# Altium schematic sheet mcu.SchDoc(mcu)
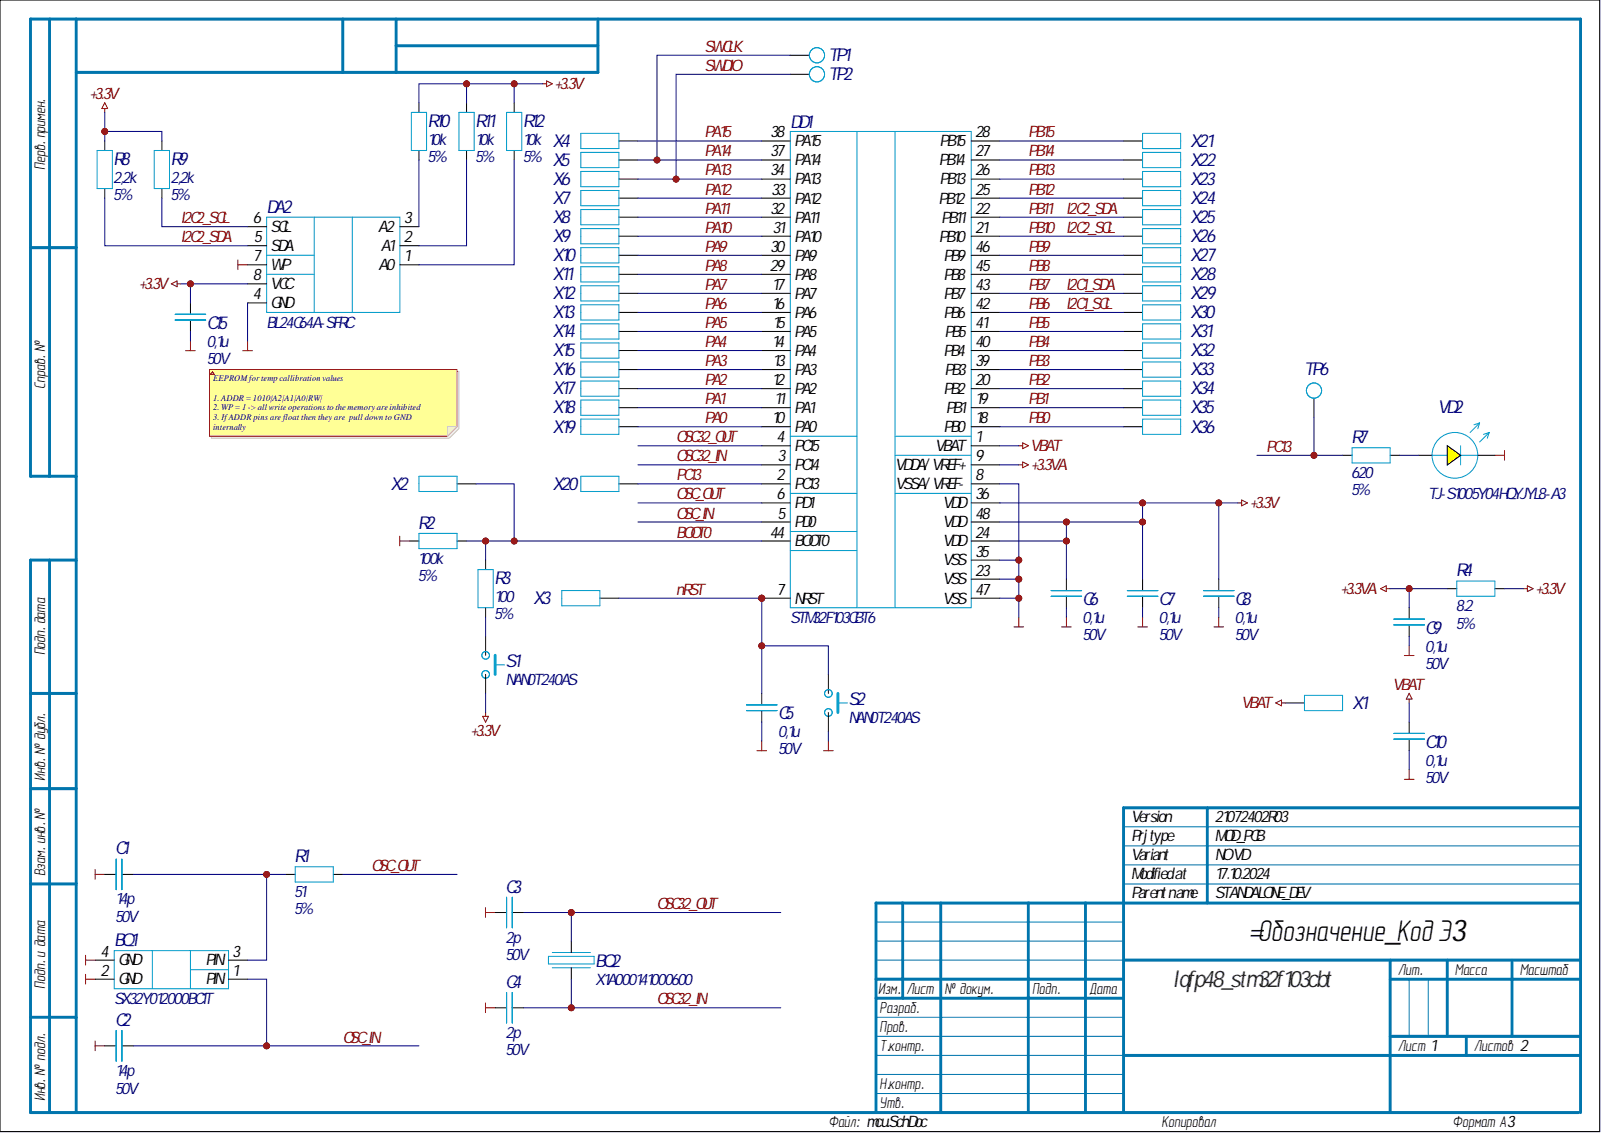
[diagram p0: mcu.SchDoc]

## Parts
BQ1  SX32Y012000BC1T  [SX32Y012000BC1T]
BQ2  X1A000141000600  [X1A000141000600]
C1  value 14p  [CAPC1005X06N]  (hidden comment: 04025U140GAT2A)
C2  value 14p  Voltage: 50V  [CAPC1005X06N]  (hidden comment: 04025U140GAT2A)
C3  value 2p  Voltage: 50V  [CAPC1005X06N]  (hidden comment: GRM1555C1H2R0BA01D)
C4  value 2p  Voltage: 50V  [CAPC1005X06N]  (hidden comment: GRM1555C1H2R0BA01D)
C5  value 0,1u  Voltage: 50V  [CAPC1005X06N]  (hidden comment: CC0402KRX7R9BB104)
C6  value 0,1u  Voltage: 50V  [CAPC1005X06N]  (hidden comment: CC0402KRX7R9BB104)
C7  value 0,1u  Voltage: 50V  [CAPC1005X06N]  (hidden comment: CC0402KRX7R9BB104)
C8  value 0,1u  Voltage: 50V  [CAPC1005X06N]  (hidden comment: CC0402KRX7R9BB104)
C9  value 0,1u  Voltage: 50V  [CAPC1005X06N]  (hidden comment: CC0402KRX7R9BB104)
C10  value 0,1u  Voltage: 50V  [CAPC1005X06N]  (hidden comment: CC0402KRX7R9BB104)
C15  value 0,1u  Voltage: 50V  [CAPC1005X06N]  (hidden comment: CC0402KRX7R9BB104)
DA2  BL24C64A-SFRC  [TSOP65P640X120-8N]
DD1  STM32F103CBT6  [TSQFP50P900X900X160-48N]
R1  value 51  Accuracy: 5%  [RESC0603X03N]  (hidden comment: RC0201JR-0751RL)
R2  value 100k  Accuracy: 5%  [RESC1005X03N]  (hidden comment: RC0402JR-07100KL)
R3  value 100  Accuracy: 5%  [RESC1005X03N]  (hidden comment: RC0402JR-07100RL)
R4  value 8.2  Accuracy: 5%  [RESC1005X03N]  (hidden comment: RC0402JR-078R2L)
R7  value 620  Accuracy: 5%  [RESC1005X03N]  (hidden comment: RC0402JR-07620RL)
R8  value 2,2k  Accuracy: 5%  [RESC1005X03N]  (hidden comment: RC0402JR-072K2L)
R9  value 2,2k  Accuracy: 5%  [RESC1005X03N]  (hidden comment: RC0402JR-072K2L)
R10  value 10k  Accuracy: 5%  [RESC1005X03N]  (hidden comment: RC0402JR-0710KL)
R11  value 10k  Accuracy: 5%  [RESC1005X03N]  (hidden comment: RC0402JR-0710KL)
R12  value 10k  Accuracy: 5%  [RESC1005X03N]  (hidden comment: RC0402JR-0710KL)
S1  NAN0T240AS  [NAN0T240AS]
S2  NAN0T240AS  [NAN0T240AS]
TP1  Test Point 1mm  [Test Point 1mm]  (value not drawn)  (no BOM)
TP2  Test Point 1mm  [Test Point 1mm]  (value not drawn)  (no BOM)
TP6  Test Point 1mm  [Test Point 1mm]  (value not drawn)  (no BOM)
VD2  TJ-S1005Y04HQYJYL8-A3  [LED_0402_YELLOW]
X1  (no value)  [Socket_th_06_10]
X2  (no value)  [Socket_th_06_10]
X3  (no value)  [Socket_th_06_10]
X4  (no value)  [Socket_th_06_10]
X5  (no value)  [Socket_th_06_10]
X6  (no value)  [Socket_th_06_10]
X7  (no value)  [Socket_th_06_10]
X8  (no value)  [Socket_th_06_10]
X9  (no value)  [Socket_th_06_10]
X10  (no value)  [Socket_th_06_10]
X11  (no value)  [Socket_th_06_10]
X12  (no value)  [Socket_th_06_10]
X13  (no value)  [Socket_th_06_10]
X14  (no value)  [Socket_th_06_10]
X15  (no value)  [Socket_th_06_10]
X16  (no value)  [Socket_th_06_10]
X17  (no value)  [Socket_th_06_10]
X18  (no value)  [Socket_th_06_10]
X19  (no value)  [Socket_th_06_10]
X20  (no value)  [Socket_th_06_10]
X21  (no value)  [Socket_th_06_10]
X22  (no value)  [Socket_th_06_10]
X23  (no value)  [Socket_th_06_10]
X24  (no value)  [Socket_th_06_10]
X25  (no value)  [Socket_th_06_10]
X26  (no value)  [Socket_th_06_10]
X27  (no value)  [Socket_th_06_10]
X28  (no value)  [Socket_th_06_10]
X29  (no value)  [Socket_th_06_10]
X30  (no value)  [Socket_th_06_10]
X31  (no value)  [Socket_th_06_10]
X32  (no value)  [Socket_th_06_10]
X33  (no value)  [Socket_th_06_10]
X34  (no value)  [Socket_th_06_10]
X35  (no value)  [Socket_th_06_10]
X36  (no value)  [Socket_th_06_10]

## Nets
+3.3V: C6.2 C7.2 C8.2 C15.2 DA2.8(VCC) DD1.24(VDD) DD1.36(VDD) DD1.48(VDD) R4.2 R8.2 R9.2 R10.1 R11.1 R12.1 S1.2
+3.3VA: C9.2 DD1.9(VDDA/_VREF+) R4.1
GND: BQ1.2(GND) BQ1.4(GND) C1.1 C2.1 C3.1 C4.1 C5.1 C6.1 C7.1 C8.1 C9.1 C10.1 C15.1 DA2.4(GND) DA2.7(WP) DD1.8(VSSA/_VREF-) DD1.23(VSS) DD1.35(VSS) DD1.47(VSS) R2.2 S2.2 VD2.1
VBAT: C10.2 DD1.1(VBAT) X1.0
BOOT0: DD1.44(BOOT0) R2.1 R3.1 X2.0
I2C1_SCL: DD1.42(PB6) X30.0
I2C1_SDA: DD1.43(PB7) X29.0
I2C2_SCL: DA2.6(SCL) DD1.21(PB10) R9.1 X26.0
I2C2_SDA: DA2.5(SDA) DD1.22(PB11) R8.1 X25.0
nRST: C5.2 DD1.7(NRST) S2.1 X3.0
OSC32_IN: BQ2.2 C4.2 DD1.3(PC14)
OSC32_OUT: BQ2.1 C3.2 DD1.4(PC15)
OSC_IN: BQ1.1(PIN) C2.2 DD1.5(PD0)
OSC_OUT: DD1.6(PD1) R1.2
PA0: DD1.10(PA0) X19.0
PA1: DD1.11(PA1) X18.0
PA2: DD1.12(PA2) X17.0
PA3: DD1.13(PA3) X16.0
PA4: DD1.14(PA4) X15.0
PA5: DD1.15(PA5) X14.0
PA6: DD1.16(PA6) X13.0
PA7: DD1.17(PA7) X12.0
PA8: DD1.29(PA8) X11.0
PA9: DD1.30(PA9) X10.0
PA10: DD1.31(PA10) X9.0
PA11: DD1.32(PA11) X8.0
PA12: DD1.33(PA12) X7.0
PA13: DD1.34(PA13) TP2.1 X6.0
PA14: DD1.37(PA14) TP1.1 X5.0
PA15: DD1.38(PA15) X4.0
PB0: DD1.18(PB0) X36.0
PB1: DD1.19(PB1) X35.0
PB2: DD1.20(PB2) X34.0
PB3: DD1.39(PB3) X33.0
PB4: DD1.40(PB4) X32.0
PB5: DD1.41(PB5) X31.0
PB8: DD1.45(PB8) X28.0
PB9: DD1.46(PB9) X27.0
PB12: DD1.25(PB12) X24.0
PB13: DD1.26(PB13) X23.0
PB14: DD1.27(PB14) X22.0
PB15: DD1.28(PB15) X21.0
PC13: DD1.2(PC13) R7.1 TP6.1 X20.0
NetBQ1_3: BQ1.3(PIN) C1.2 R1.1
NetDA2_1: DA2.1(A0) R12.2
NetDA2_2: DA2.2(A1) R11.2
NetDA2_3: DA2.3(A2) R10.2
NetR3_2: R3.2 S1.1
NetR7_2: R7.2 VD2.2

## Library data of the parts
BQ1 = SX32Y012000BC1T: SMD3225-4P Crystals ROHS; Manufacturer: TKD; Cl: 12pF; Co: 3pF; Database Table Name: Resonators$; ESR: 100 Ohm; Frequency: 12 MHz; Frequency Stability: +-10ppm; Component Kind: Standard; Library Name: Crystals .DbLib; Mount: SMD; Package: SMD3225-4P; Part Number: SX32Y012000BC1T
BQ2 = X1A000141000600: Passive Crystal Oscillators 6pF 35KΩ ±20ppm -40℃~+85℃ SMD3215_2P 32.768KHz; Manufacturer: EPSON; Co: 1pF; Database Table Name: Resonators$; ESR: 35 kOhm; Frequency Stability: +-20ppm; Cl: 6pF; Component Kind: Standard; Frequency: 32.768kHz; Library Name: Crystals .DbLib; Mount: SMD; Package: SMD3215_2P; Part Number: X1A000141000600
C1 C2 = 04025U140GAT2A: C_0402 NPO 50V 14p; Manufacturer: AVX; Database Table Name: 0402$; TCE: NP0; Tolerance: 2%; Component Kind: Standard; Library Name: Capacitors.DbLib; Mount: SMD; Package: 0402; Part Number: 04025U140GAT2A
  C1: Voltage: 50V
C3 C4 = GRM1555C1H2R0BA01D: C_0402 NPO 50V 2p; Manufacturer: MURATA; Database Table Name: 0402$; TCE: NP0; Tolerance: 0.1p; Component Kind: Standard; Library Name: Capacitors.DbLib; Mount: SMD; Package: 0402; Part Number: GRM1555C1H2R0BA01D
C5-C10 C15 = CC0402KRX7R9BB104: C_0402 X7R 50V 0.1u; Manufacturer: YAGEO; Database Table Name: 0402$; Tolerance: 10%; Component Kind: Standard; Library Name: Capacitors.DbLib; Mount: SMD; Package: 0402; Part Number: CC0402KRX7R9BB104; TCE: X7R
DA2 = BL24C64A-SFRC: BL24C64A 64Kbits (8,192×8); Manufacturer: BELLING; Database Table Name: Memory$; Component Kind: Standard; Library Name: ICs.DbLib; Mount: SMD; Package: TSSOP-8; Part Number: BL24C64A-SFRC
DD1 = STM32F103CBT6: Medium-density performance line ARM®-based 32-bit MCU with 64  or 128 KB Flash, USB, CAN, 7 timers, 2 ADCs, 9 com. interfaces; Manufacturer: ST; Database Table Name: MCU$; Component Kind: Standard; Library Name: ICs.DbLib; Mount: SMD; Part Number: STM32F103CBT6
R1 = RC0201JR-0751RL: R_0201 5% 51; Manufacturer: YAGEO; Database Table Name: 0201$; Power: 0,05W; Component Kind: Standard; Library Name: Resistors.DbLib; Package: 0201; Part Number: RC0201JR-0751RL
R2 = RC0402JR-07100KL: R_0402 5% 100k; Manufacturer: YAGEO; Database Table Name: 0402$; Power: 0,0625W; Component Kind: Standard; Library Name: Resistors.DbLib; Package: 0402; Part Number: RC0402JR-07100KL
R3 = RC0402JR-07100RL: R_0402 5% 100; Manufacturer: YAGEO; Database Table Name: 0402$; Power: 0,0625W; Component Kind: Standard; Library Name: Resistors.DbLib; Package: 0402; Part Number: RC0402JR-07100RL
R4 = RC0402JR-078R2L: R_0402 5% 8.2; Manufacturer: YAGEO; Database Table Name: 0402$; Power: 0,0625W; Component Kind: Standard; Library Name: Resistors.DbLib; Package: 0402; Part Number: RC0402JR-078R2L
R7 = RC0402JR-07620RL: R_0402 5% 620; Manufacturer: YAGEO; Database Table Name: 0402$; Power: 0,0625W; Component Kind: Standard; Library Name: Resistors.DbLib; Package: 0402; Part Number: RC0402JR-07620RL
R8 R9 = RC0402JR-072K2L: R_0402 5% 2.2k; Manufacturer: YAGEO; Database Table Name: 0402$; Power: 0,0625W; Component Kind: Standard; Library Name: Resistors.DbLib; Package: 0402; Part Number: RC0402JR-072K2L
R10-R12 = RC0402JR-0710KL: R_0402 5% 10k; Manufacturer: YAGEO; Database Table Name: 0402$; Power: 0,0625W; Component Kind: Standard; Library Name: Resistors.DbLib; Package: 0402; Part Number: RC0402JR-0710KL
S1 S2 = NAN0T240AS: The Most Compact Nano Size Tact Switch; Manufacturer: C&K; Database Table Name: Buttons$; Component Kind: Standard; Library Name: Buttons.DbLib; Mount: SMD; Part Number: NAN0T240AS
TP1 TP2 TP6 = Test Point 1mm: Debug test point. No solder.; Manufacturer: None; Database Table Name: Tst Points$; ClassName: TestPoint; Library Name: Connectors.DbLib; Part Number: Test Point 1mm
VD2 = TJ-S1005Y04HQYJYL8-A3: LED yellow 0402 585~595nm 80~20mcd Front Mounting; Manufacturer: TOGIALED; Database Table Name: LED$; Component Kind: Standard; Library Name: Diodes.DbLib; Package: 0402; Part Number: TJ-S1005Y04HQYJYL8-A3; Type: LED
X1-X36 = Socket_th_06_10: Database Table Name: Sockets$; Component Kind: Standard; Library Name: Connectors.DbLib; Part Number: Socket_th_06_10
